# Altium schematic sheet POWER_MGMT.SchDoc
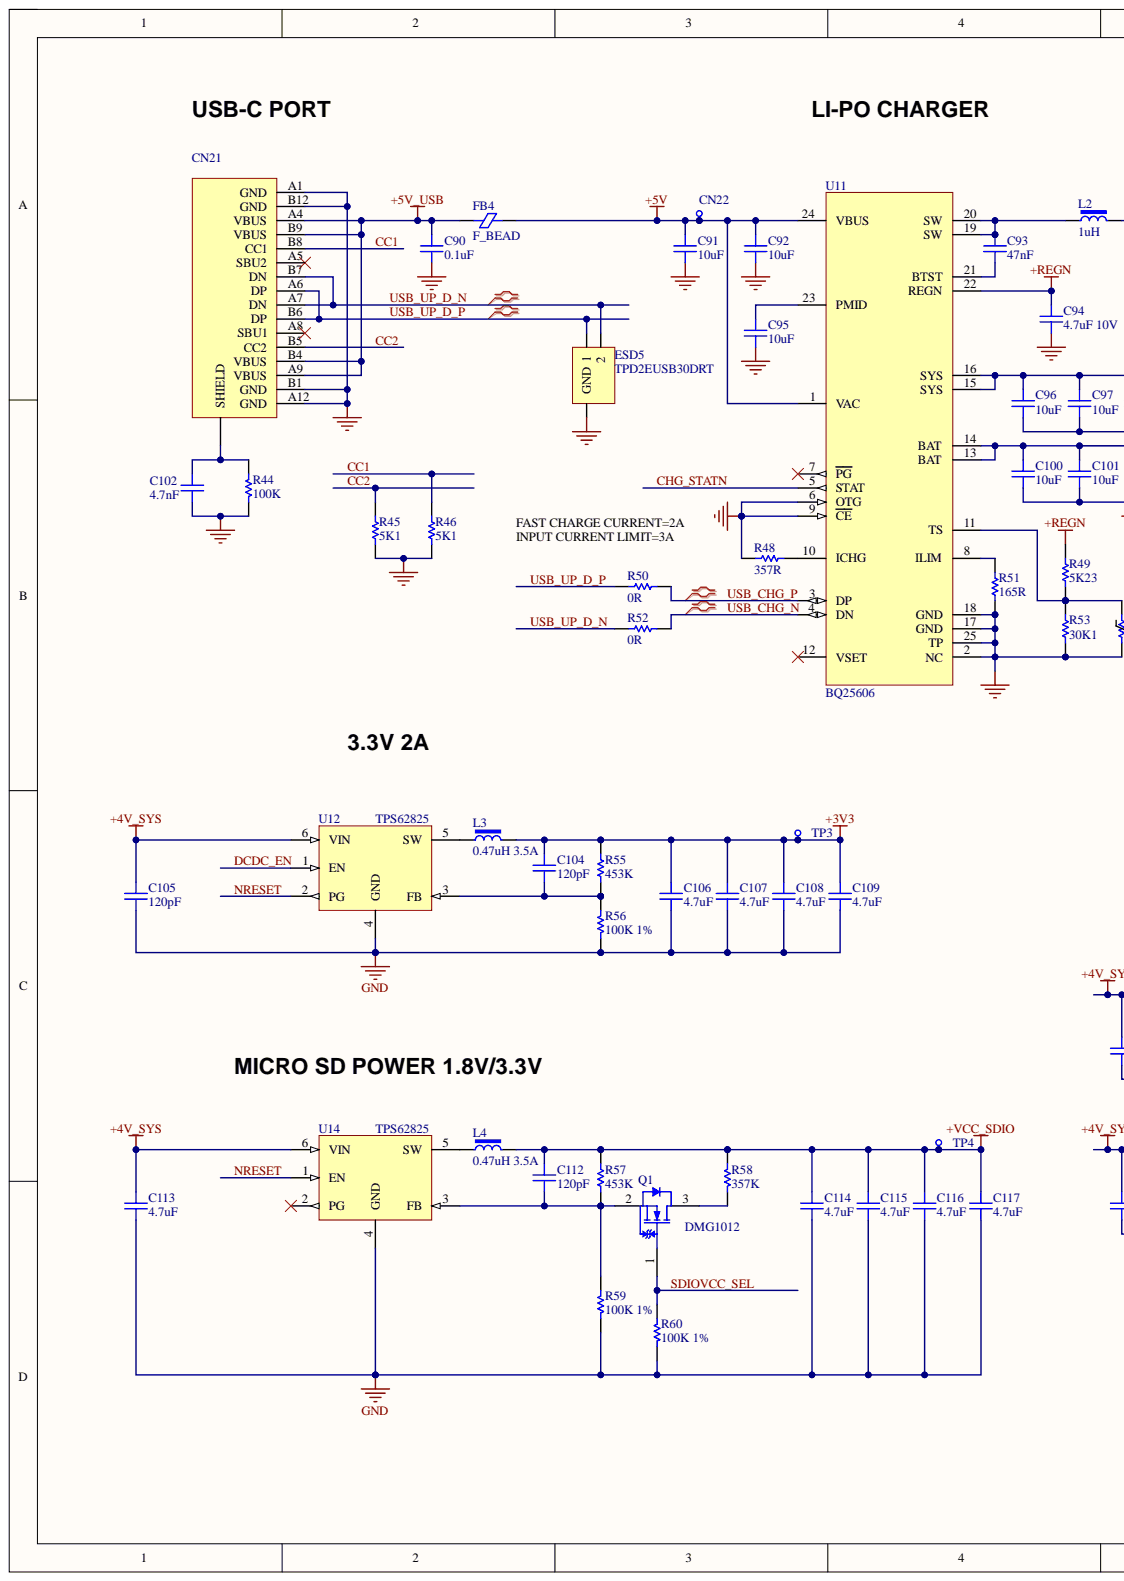
[diagram p0: POWER_MGMT.SchDoc, left]
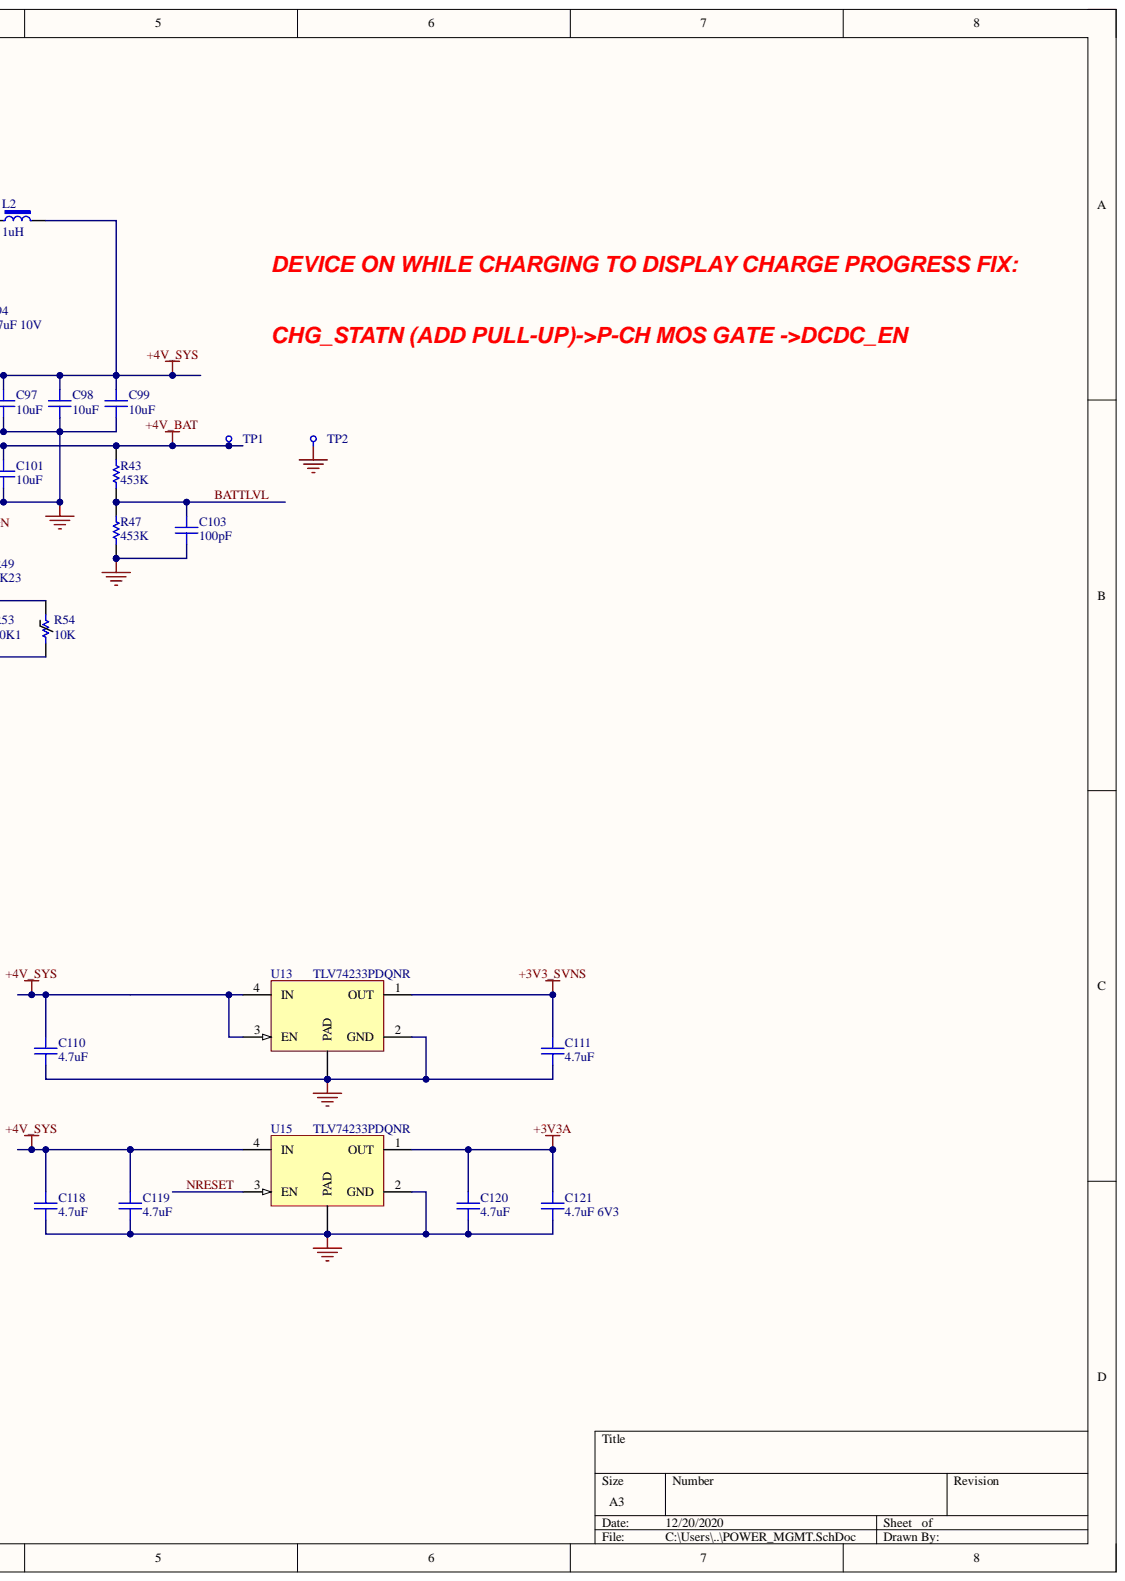
[diagram p1: POWER_MGMT.SchDoc, right]

## Parts
C90  0.1uF  [AA-0402]
C91  10uF  [AA-0402]
C92  10uF  [AA-0402]
C93  47nF  [AA-0402]
C94  4.7uF 10V  [AA-0603]
C95  10uF  [AA-0402]
C96  10uF  [AA-0402]
C97  10uF  [AA-0402]
C98  10uF  [AA-0402]
C99  10uF  [AA-0402]
C100  10uF  [AA-0402]
C101  10uF  [AA-0402]
C102  4.7nF  [AA-0402]
C103  100pF  [AA-0402]
C104  120pF  [AA-0402]
C105  120pF  [AA-0402]
C106  4.7uF  [AA-0402]
C107  4.7uF  [AA-0402]
C108  4.7uF  [AA-0402]
C109  4.7uF  [AA-0402]
C110  4.7uF  [AA-0402]
C111  4.7uF  [AA-0402]
C112  120pF  [AA-0402]
C113  4.7uF  [AA-0402]
C114  4.7uF  [AA-0402]
C115  4.7uF  [AA-0402]
C116  4.7uF  [AA-0402]
C117  4.7uF  [AA-0402]
C118  4.7uF  [AA-0402]
C119  4.7uF  [AA-0402]
C120  4.7uF  [AA-0402]
C121  4.7uF 6V3  [AA-0402]
CN21  (no value)  [U262-16XN-4BVC11]
CN22  TEST POINT  [TESTPAD-1MM]  (value not drawn)  (no BOM)
ESD5  TPD2EUSB30DRT  [SOT-3]
FB4  F_BEAD  [AA-0603]
L2  1uH  [AA-0806]
L3  0.47uH 3.5A  [AA-0806]
L4  0.47uH 3.5A  [AA-0806]
Q1  DMG1012  [SOT-523]
R43  453K  [AA-0402]
R44  100K  [AA-0402]
R45  5K1  [AA-0402]
R46  5K1  [AA-0402]
R47  453K  [AA-0402]
R48  357R  [AA-0402]
R49  5K23  [AA-0402]
R50  0R  [AA-0402]
R51  165R  [AA-0402]
R52  0R  [AA-0402]
R53  30K1  [AA-0402]
R54  10K  [AA-0402]
R55  453K  [AA-0402]
R56  100K 1%  Tolerance: 1%  [AA-0402]
R57  453K  [AA-0402]
R58  357K  [AA-0402]
R59  100K 1%  [AA-0402]
R60  100K 1%  Tolerance: 1%  [AA-0402]
TP1  TEST POINT  [TESTPAD-2.5x4MM-SQUARE]  (value not drawn)  (no BOM)
TP2  TEST POINT  [TESTPAD-2.5x4MM-SQUARE]  (value not drawn)  (no BOM)
TP3  TEST POINT  [TESTPAD-0.6MM]  (value not drawn)  (no BOM)
TP4  TEST POINT  [TESTPAD-0.6MM]  (value not drawn)  (no BOM)
U11  BQ25606  [TI-RGE-24-VQFN-24]
U12  TPS62825  [TI-DMQ6]
U13  TLV74233PDQNR  [TI-DQN(X2SON)]
U14  TPS62825  [TI-DMQ6]
U15  TLV74233PDQNR  [TI-DQN(X2SON)]

## Nets
+3V3: C104.2 C106.2 C107.2 C108.2 C109.2 L3.2 R55.2 TP3.TST
+3V3_SVNS: C111.2 U13.1(OUT)
+3V3A: C120.2 C121.2 U15.1(OUT)
+4V_BAT: C100.2 C101.2 R43.2 TP1.TST U11.13(BAT) U11.14(BAT)
+4V_SYS: C96.2 C97.2 C98.2 C99.2 C105.2 C110.2 C113.2 C118.2 C119.2 L2.2 U11.15(SYS) U11.16(SYS) U12.6(VIN) U13.3(EN) U13.4(IN) U14.6(VIN) U15.4(IN)
+5V: C91.2 C92.2 CN22.TST FB4.2 U11.1(VAC) U11.24(VBUS)
+5V_USB: C90.1 CN21.A4(VBUS) CN21.A9(VBUS) CN21.B4(VBUS) CN21.B9(VBUS) FB4.1
+REGN: C94.2 R49.1 U11.22(REGN)
+VCC_SDIO: C112.2 C114.2 C115.2 C116.2 C117.2 L4.2 R57.2 R58.1 TP4.TST
GND: C90.2 C91.1 C92.1 C94.1 C95.1 C96.1 C97.1 C98.1 C99.1 C100.1 C101.1 C102.1 C103.1 C105.1 C106.1 C107.1 C108.1 C109.1 C110.1 C111.1 C113.1 C114.1 C115.1 C116.1 C117.1 C118.1 C119.1 C120.1 C121.1 CN21.A1(GND) CN21.A12(GND) CN21.B1(GND) CN21.B12(GND) ESD5.3 R44.2 R45.1 R46.1 R47.1 R48.2 R51.2 R53.2 R54.1 R56.2 R59.2 R60.2 TP2.TST U11.2(NC) U11.6(OTG) U11.9(CE) U11.17(GND) U11.18(GND) U11.25(TP) U12.4(GND) U13.2(GND) U13.5(PAD) U14.4(GND) U15.2(GND) U15.5(PAD)
BATTLVL: C103.2 R43.1 R47.2
CC1: CN21.B8(CC1) R46.2
CC2: CN21.B5(CC2) R45.2
CHG_STATN: U11.5(STAT)
DCDC_EN: U12.1(EN)
NRESET: U12.2(PG) U14.1(EN) U15.3(EN)
SDIOVCC_SEL: Q1.1 R60.1
USB_CHG_N: R52.1 U11.4(DN)
USB_CHG_P: R50.1 U11.3(DP)
USB_UP_D_N: CN21.A7(DN) CN21.B7(DN) ESD5.2 R52.2
USB_UP_D_P: CN21.A6(DP) CN21.B6(DP) ESD5.1 R50.2
NetC93_1: C93.1 U11.21(BTST)
NetC93_2: C93.2 L2.1 U11.19(SW) U11.20(SW)
NetC95_2: C95.2 U11.23(PMID)
NetC102_2: C102.2 CN21.SHIELD R44.1
NetC104_1: C104.1 R55.1 R56.1 U12.3(FB)
NetC112_1: C112.1 Q1.2 R57.1 R59.1 U14.3(FB)
NetL3_1: L3.1 U12.5(SW)
NetL4_1: L4.1 U14.5(SW)
NetQ1_3: Q1.3 R58.2
NetR48_1: R48.1 U11.10(ICHG)
NetR49_2: R49.2 R53.1 R54.2 U11.11(TS)
NetR51_1: R51.1 U11.8(ILIM)

Not connected: CN21 A5(SBU2) A8(SBU1); U11 7(PG) 12(VSET); U14 2(PG)

## Library data of the parts
C90-C92 C95-C101 = 00005500W: 100nF 16V 10% X7R 0402  -55+125; Database Table Name: Capacitor; Component Kind: Standard; Library Name: ALT_DB.DBLIB
  C90: Value: 0.1uF
C93 C94 = 00005500W: Database Table Name: Capacitor; Component Kind: Standard; Library Name: ALT_DB.DBLIB
C102 = 00005465: 4.7nF 25V +-10% X7R  0402  -55+125; Database Table Name: Capacitor; Component Kind: Standard; Library Name: ALT_DB.DBLIB
C103 = 00005448: 100pF 25V +-5% COG 0-30ppm 0402  -55+125; Database Table Name: Capacitor; Component Kind: Standard; Library Name: ALT_DB.DBLIB
C104 C105 C112 = 00005453: 120pF 50V +-5% COG  0402  -55+125; Manufacturer: MURATA; Manufacturer Part Number: GRM1555C1H121JA01D; Allegro PCB Footprint: c1005_TM2; COMPHEIGHT: 0.5; Database Table Name: Capacitor; Komentaras: $; ActivepartsID: http://search.murata.co.jp/Ceramy/image/img/PDF/ENG/GRM1555C1H121JA01.pdf; Availability: In Stock; Component Kind: Standard; Data: 10/11/2010; Distributor: Digi-Key; Distributor Part Number: 490-1292-2-ND; Library Name: ALT_DB.DBLIB; Package: 10000T&R; Part Number: 00005453; Part Type: Ceramic\1005; Price: 0.005; SMD: Yes; Tolerance: +-5%; Value: 120pF; Voltage: 50V; Datasheet: E:\pdf\KONDENSATORIAI\CER_smd\GRM1555C1H121JA01.pdf
C106-C111 C113-C121 = 00005453: Database Table Name: Capacitor; Component Kind: Standard; Library Name: ALT_DB.DBLIB
  C106-C111 C113-C120: Value: 4.7uF
  C121: Value: 4.7uF 6V3
CN21 = 72300341D: USB - C USB 3.1, Superspeed+ Receptacle Connector 16 Position SMT, RA;max3A;-30+85; Application_BuildNumber: 21.0.8.223; Database Table Name: Connectors; Component Kind: Standard; Library Name: ALT_DB.DBLIB
CN22 TP1-TP4 = TESTPOINT: Library Name: ULTIMA_LIB.SCHLIB
L2 = 20100044D: Manufacturer: Littelfuse; Manufacturer Part Number: LPWI201610SR47T; Allegro PCB Footprint: DFE_20x16x09; COMPHEIGHT: 1.0; Database Table Name: Inductors; Gaminys: 8DEV; Komentaras: $; Tolerance: 20%; Value: 0.47uH 3.5A; ActivepartsID: https://eu.mouser.com/datasheet/2/240/Littelfuse_Power_Inductor_LPWI_Datasheet.pdf-1372344.pdf; Component Kind: Standard; Data: 9/20/2019; Distributor: Mouser; Distributor Part Number: 576-LPWI201610SR47T; Library Name: ALT_DB.DBLIB; Package: 3000T&R; Part Number: 20100044D; Part Type: Power Inductor\Shielded; Price: 0.11342; SMD: Yes; Datasheet: E:\pdf\induktyvumai\smd\Littelfuse_Power_Inductor_LPWI_Datasheet.pdf-1372344.pdf
L3 L4 = 20100044D: Shielded SMD Power Inductors ser LPW; 0.47uH Rdc=25mOhm;Irms=3.6A;Isat=4.8A (0806)2.0x1.6x0.9mm;-40+125; Manufacturer: Littelfuse; Manufacturer Part Number: LPWI201610SR47T; Allegro PCB Footprint: DFE_20x16x09; COMPHEIGHT: 1.0; Gaminys: 8DEV; Komentaras: $; Tolerance: 20%; ActivepartsID: https://eu.mouser.com/datasheet/2/240/Littelfuse_Power_Inductor_LPWI_Datasheet.pdf-1372344.pdf; Component Kind: Standard; Data: 9/20/2019; Database Table Name: Inductors; Distributor: Mouser; Distributor Part Number: 576-LPWI201610SR47T; Library Name: ALT_DB.DBLIB; Package: 3000T&R; Part Number: 20100044D; Part Type: Power Inductor\Shielded; Price: 0.11342; SMD: Yes; Value: 0.47uH 3.5A; Datasheet: E:\pdf\induktyvumai\smd\Littelfuse_Power_Inductor_LPWI_Datasheet.pdf-1372344.pdf
Q1 = (no symbol name): Small-Signal MOSFET,N-chan. 20V, SOT-523, RDSon=0,4Ohm, 0.63A, -55+150(TJ); Manufacturer: Diodes Incorporated; Manufacturer Part Number: DMG1012T-7; Part Number: 40041020G; Allegro PCB Footprint: SOT523; COMPHEIGHT: 0.8; Komentaras: $; Rating: 0.28W; ActivepartsID: https://www.diodes.com/assets/Datasheets/ds31783.pdf; Availability: In Stock; Component Kind: Standard; Data: 26/09/2017; Distributor: Digi-Key; Distributor Part Number: DMG1012T-7DITR-ND; Package: 3000T&R; Part Type: Transistors\FET_N; Price: 0.05566; SMD: Yes; Datasheet: E:\pdf\tranzistoriai\ds31783.PDF; Library Name: ULTIMA_LIB.SCHLIB
R43 R47 R50 R52 R55 R57 = 10012320W: 453K 1% 0402 1/16W 100ppm Thick Film; Database Table Name: Resistor; Component Kind: Standard; Library Name: ALT_DB.DBLIB; Signal Integrity: Res; Simulation: RESISTOR
  R43 R47 R55 R57: Value: 453K
R44 = 10012142: 100K 1% 0402 1/16W 100ppm RC0402FR-100KL; Database Table Name: Resistor; Component Kind: Standard; Library Name: ALT_DB.DBLIB
R45 R46 = 10012076Wa: 5K1 1% 0402 1/16W 100ppm RC0402FR-5K1L; Database Table Name: Resistor; Component Kind: Standard; Library Name: ALT_DB.DBLIB; Signal Integrity: Res; Simulation: RESISTOR; Value: 5K1
R48 R49 R51 R53 = 10012076Wa: Database Table Name: Resistor; Component Kind: Standard; Library Name: ALT_DB.DBLIB; Signal Integrity: Res; Simulation: RESISTOR
R54 = Res_NTC: Manufacturer: Panasonic; Component Kind: Standard; Signal Integrity: Res; Simulation: RESISTOR; Value: 10K; Library Name: ULTIMA_LIB.SCHLIB
R56 R59 R60 = 10012142: 100K 1% 0402 1/16W 100ppm RC0402FR-100KL; Manufacturer: YAGEO; Manufacturer Part Number: RC0402FR-07100KL; Allegro PCB Footprint: R0402,R1005_TM2; COMPHEIGHT: 0.35; Database Table Name: Resistor; Gaminys: 8DEV; Komentaras: $; PSpice: R; ActivepartsID: http://www.yageo.com/documents/recent/PYu-RC_Group_51_RoHS_L_10.pdf; Availability: In Stock; Component Kind: Standard; Data: 5/10/2019; Distributor: Digi-Key; Distributor Part Number: 311-100KLRTR-ND; Library Name: ALT_DB.DBLIB; Package: 10000T&R; Part Number: 10012142; Part type: SMD\0402_1%; Power: 1/16W; Price: 0.00194; SMD: Yes; Value: 100K 1%; Datasheet: E:\pdf\rezistoriai\tikslus\PYu-RC_Group_51_RoHS_L_10.pdf
  R59: Tolerance: 1%
R58 = 10012142: Manufacturer: YAGEO; Manufacturer Part Number: RC0402FR-07100KL; Allegro PCB Footprint: R0402,R1005_TM2; COMPHEIGHT: 0.35; Database Table Name: Resistor; Gaminys: 8DEV; Komentaras: $; Power: 1/16W; PSpice: R; Tolerance: 1%; Value: 100K 1%; ActivepartsID: http://www.yageo.com/documents/recent/PYu-RC_Group_51_RoHS_L_10.pdf; Availability: In Stock; Component Kind: Standard; Data: 5/10/2019; Distributor: Digi-Key; Distributor Part Number: 311-100KLRTR-ND; Library Name: ALT_DB.DBLIB; Package: 10000T&R; Part Number: 10012142; Part type: SMD\0402_1%; Price: 0.00194; SMD: Yes; Datasheet: E:\pdf\rezistoriai\tikslus\PYu-RC_Group_51_RoHS_L_10.pdf
U12 U14 = 50800033D: SWITCHER 2.0A Step-Down Reguliator Uin=2.4-5.5V Uout=0,6-4V 2.2MHz;1%; VSON-HR-6(1.6x1.6mm );-40+125(TJ); Manufacturer: Texas Instruments; Manufacturer Part Number: TPS62825DMQR; Allegro PCB Footprint: VSON_HR6_05_15x15_h10_TI; COMPHEIGHT: 1.0; Database Table Name: IC; Gaminys: 8DEV; Komentaras: $; Value: TPS62825DMQR; ActivepartsID: http://www.ti.com/lit/ds/symlink/tps62825.pdf; Availability: In Stock; Component Kind: Standard; Data: 9/13/2019; Distributor: Mouser; Distributor Part Number: 595-TPS62825DMQR; Library Name: ALT_DB.DBLIB; Package: 3000 T&R; Part Number: 50800033D; Part Type: DC-DC\Adj; Price: 0.609; SMD: Yes; Datasheet: E:\pdf\mikroschemos\itampos reg\tps62825.pdf
U13 U15 = NCV8160AMX330TBG: Manufacturer: Texas Instruments; Component Kind: Standard; Library Name: EVAL-2390-9791.SCHLIB; Manufacturer Part Number: TLV74233PDQNR; Value: TLV74233PDQNR
ESD5 = TPD2EUSB30DRT: Component Kind: Standard; Library Name: ULTIMA_LIB.SCHLIB
FB4 = F_BEAD: Component Kind: Standard; Simulation: L_0402; Library Name: ULTIMA_LIB.SCHLIB
U11 = BQ25606: Component Kind: Standard; Library Name: ULTIMA_LIB.SCHLIB
